# Altium schematic sheet 232 LAB Sheet.SchDoc("232 LAB Sheet")
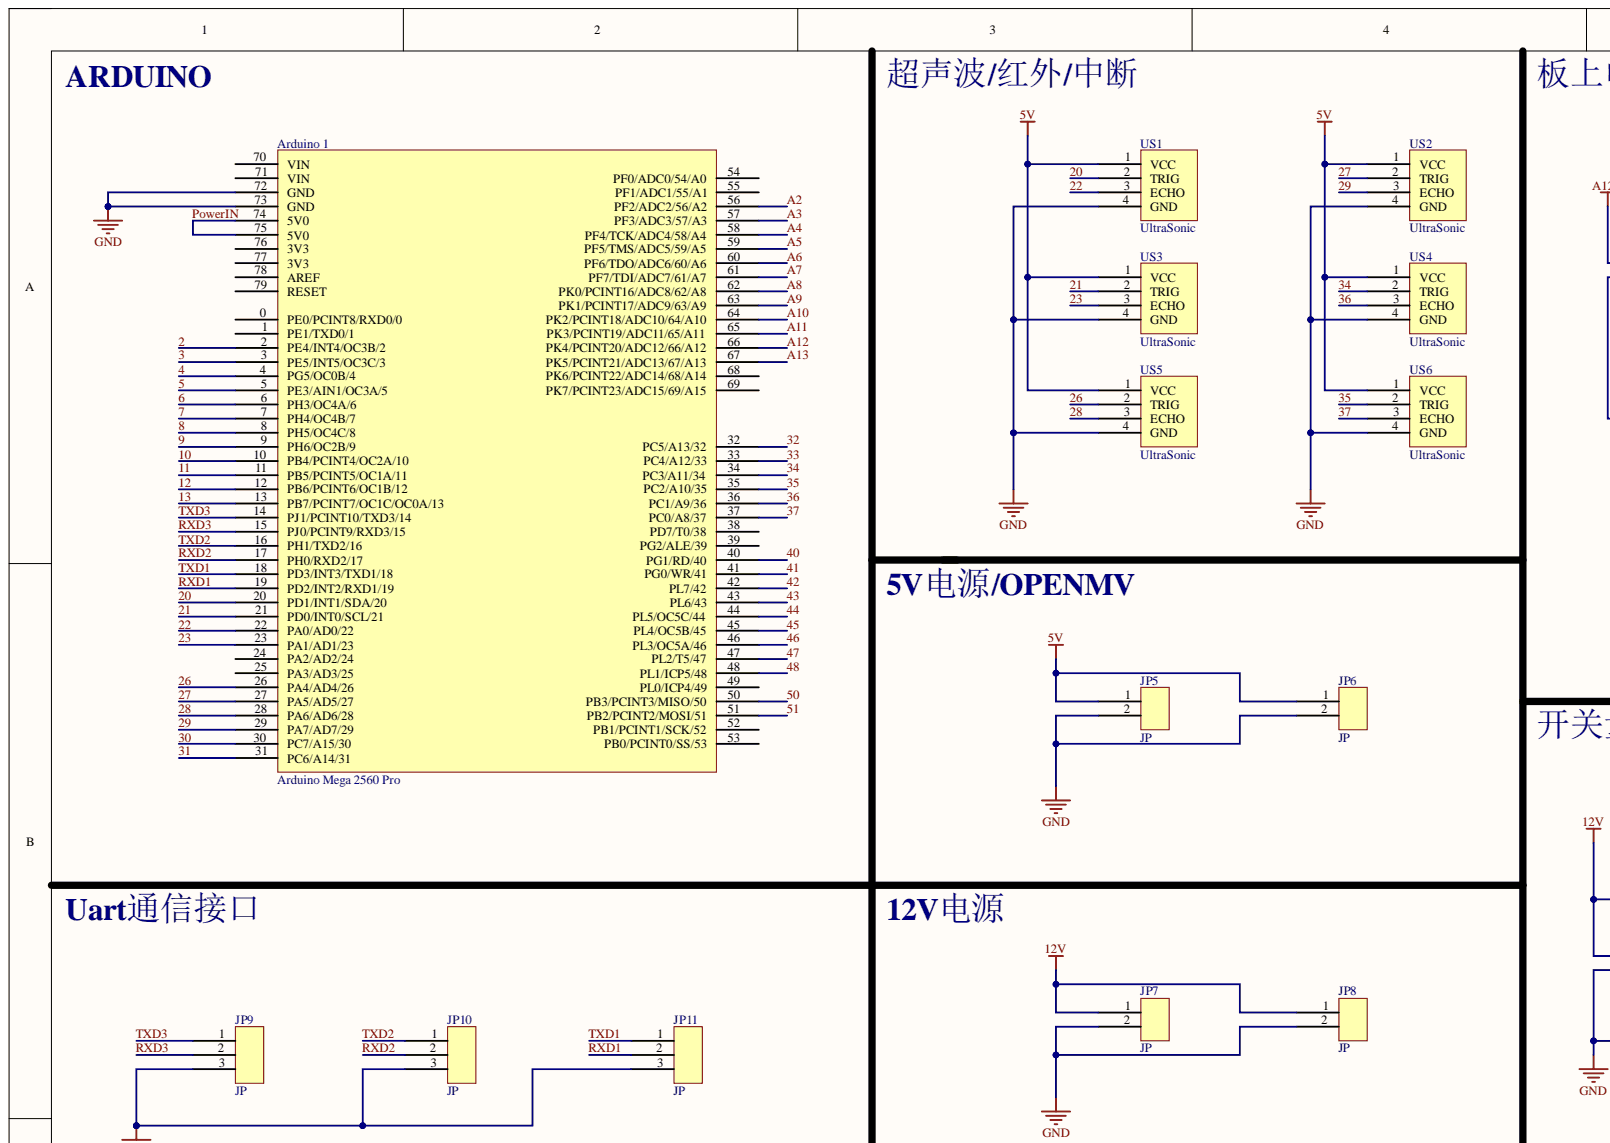
[diagram p0: 232 LAB Sheet.SchDoc, top left]
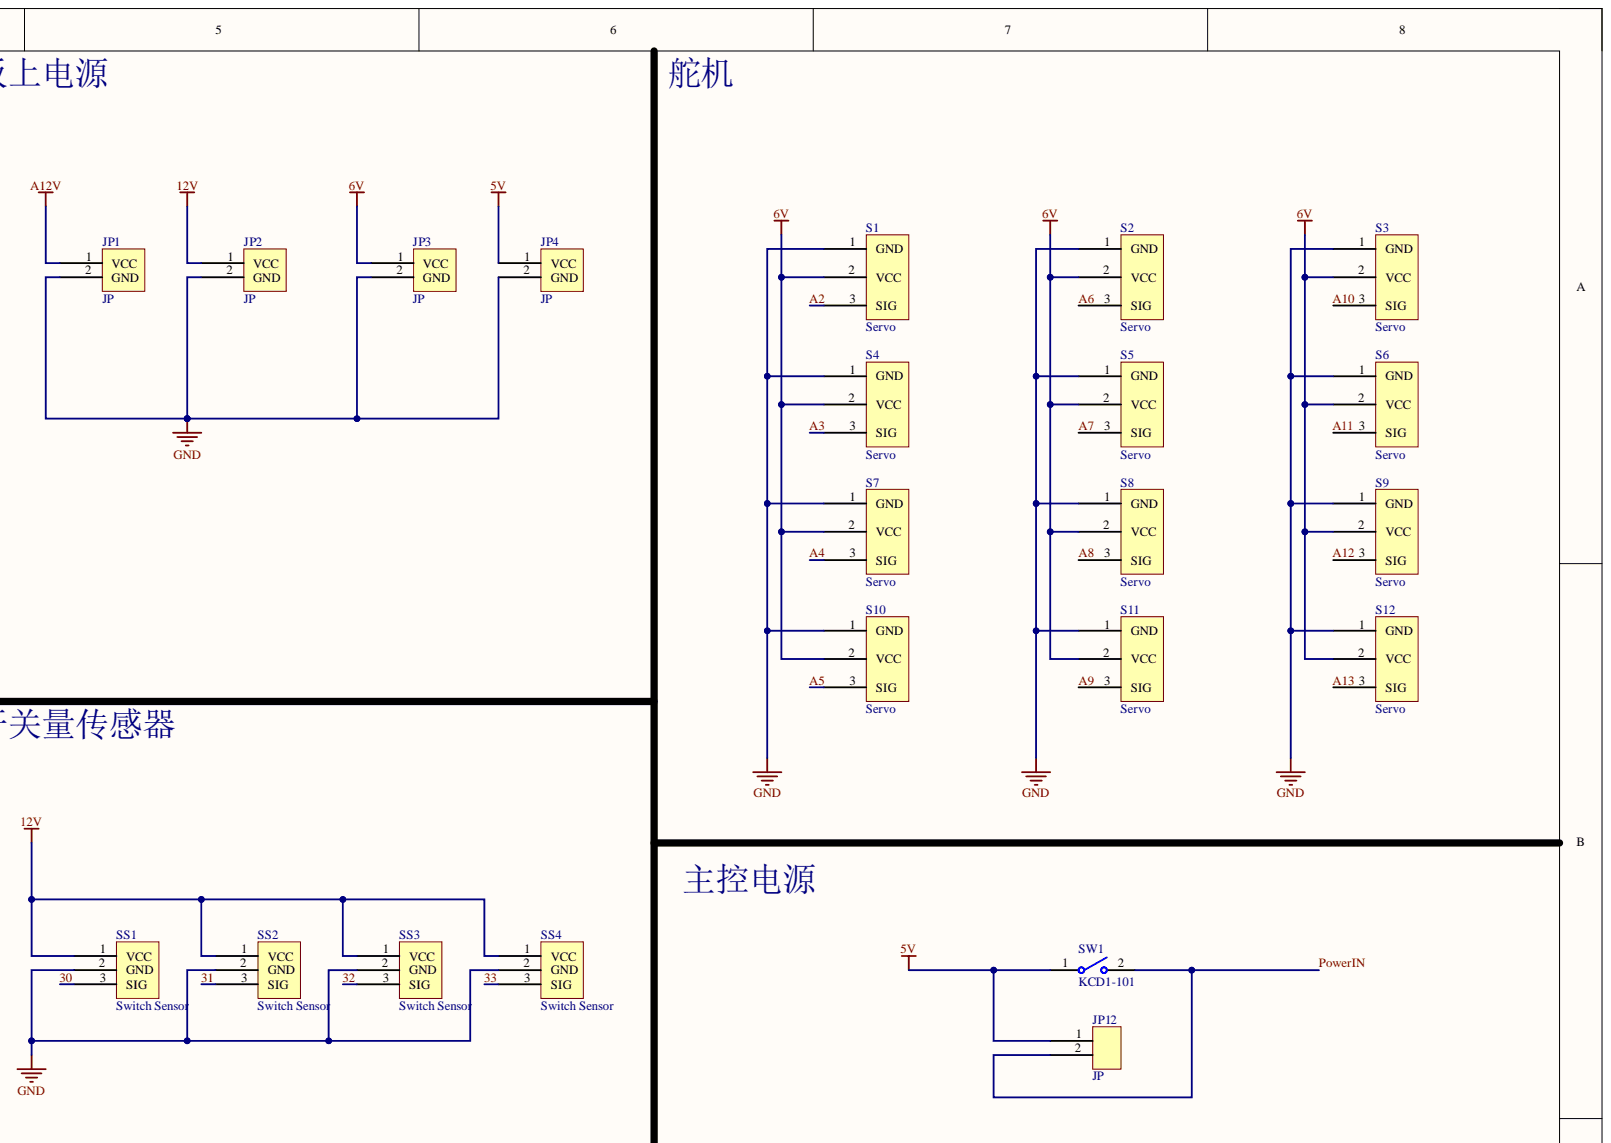
[diagram p1: 232 LAB Sheet.SchDoc, top right]
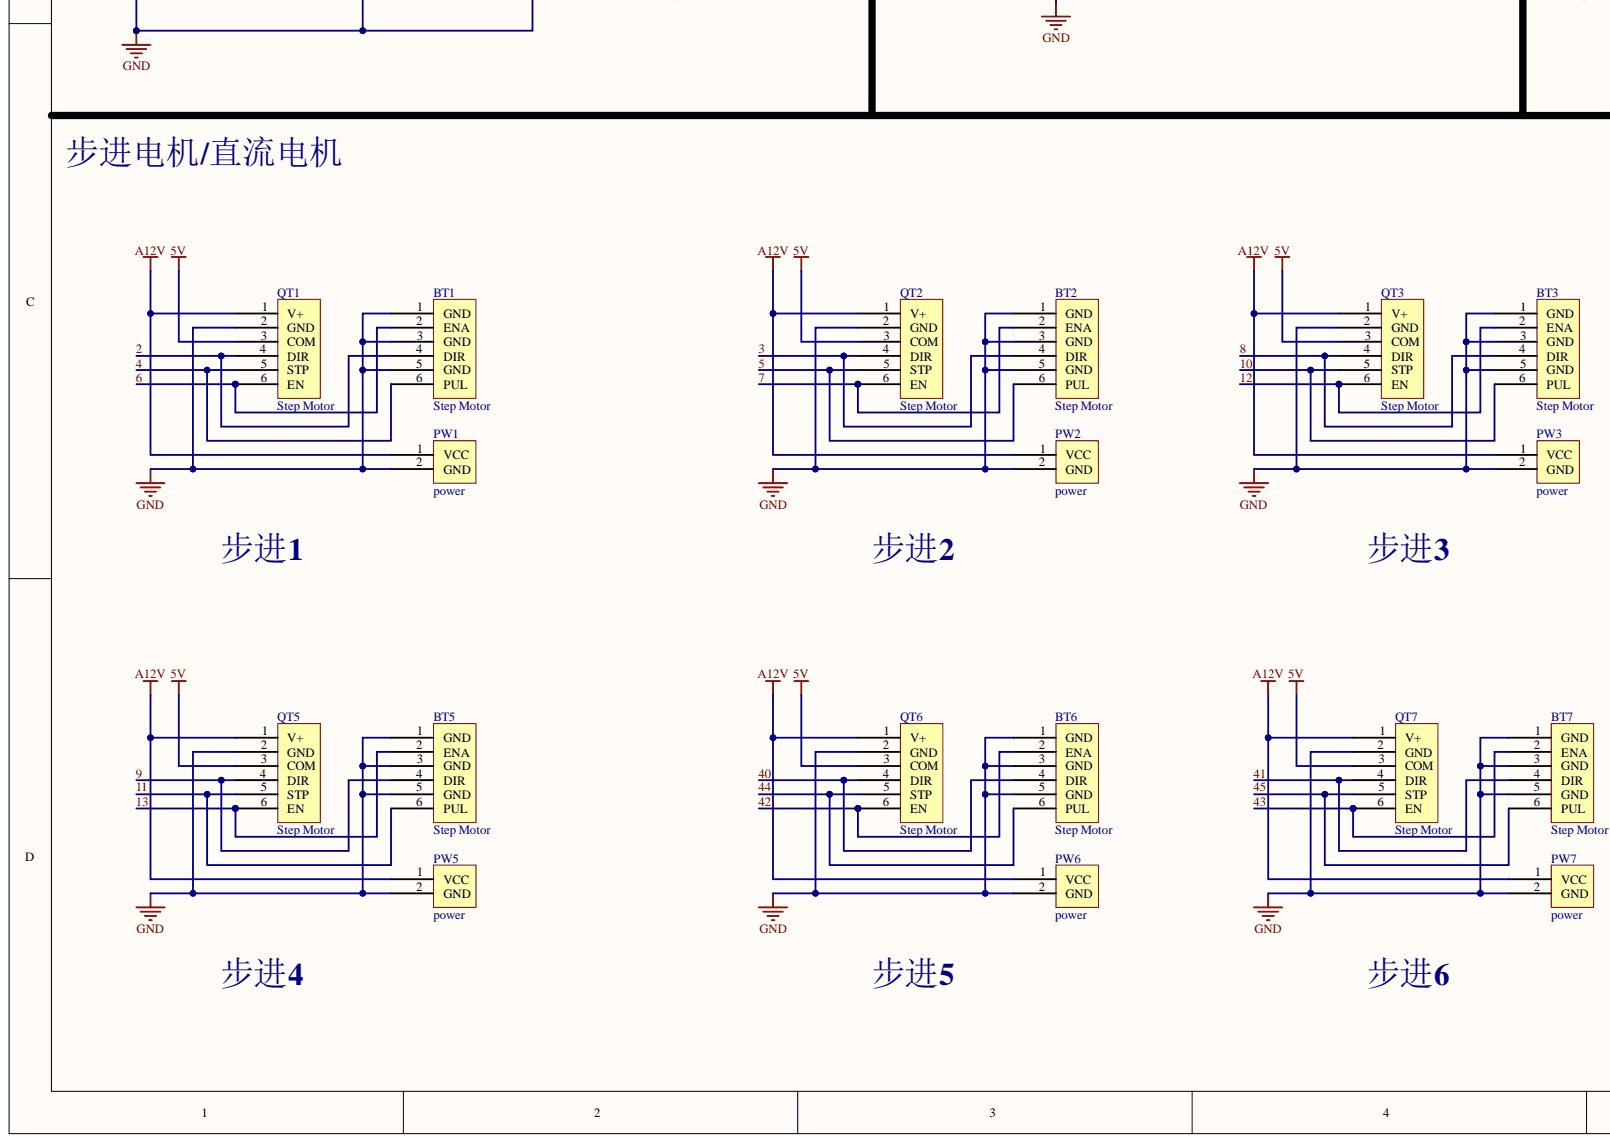
[diagram p2: 232 LAB Sheet.SchDoc, bottom left]
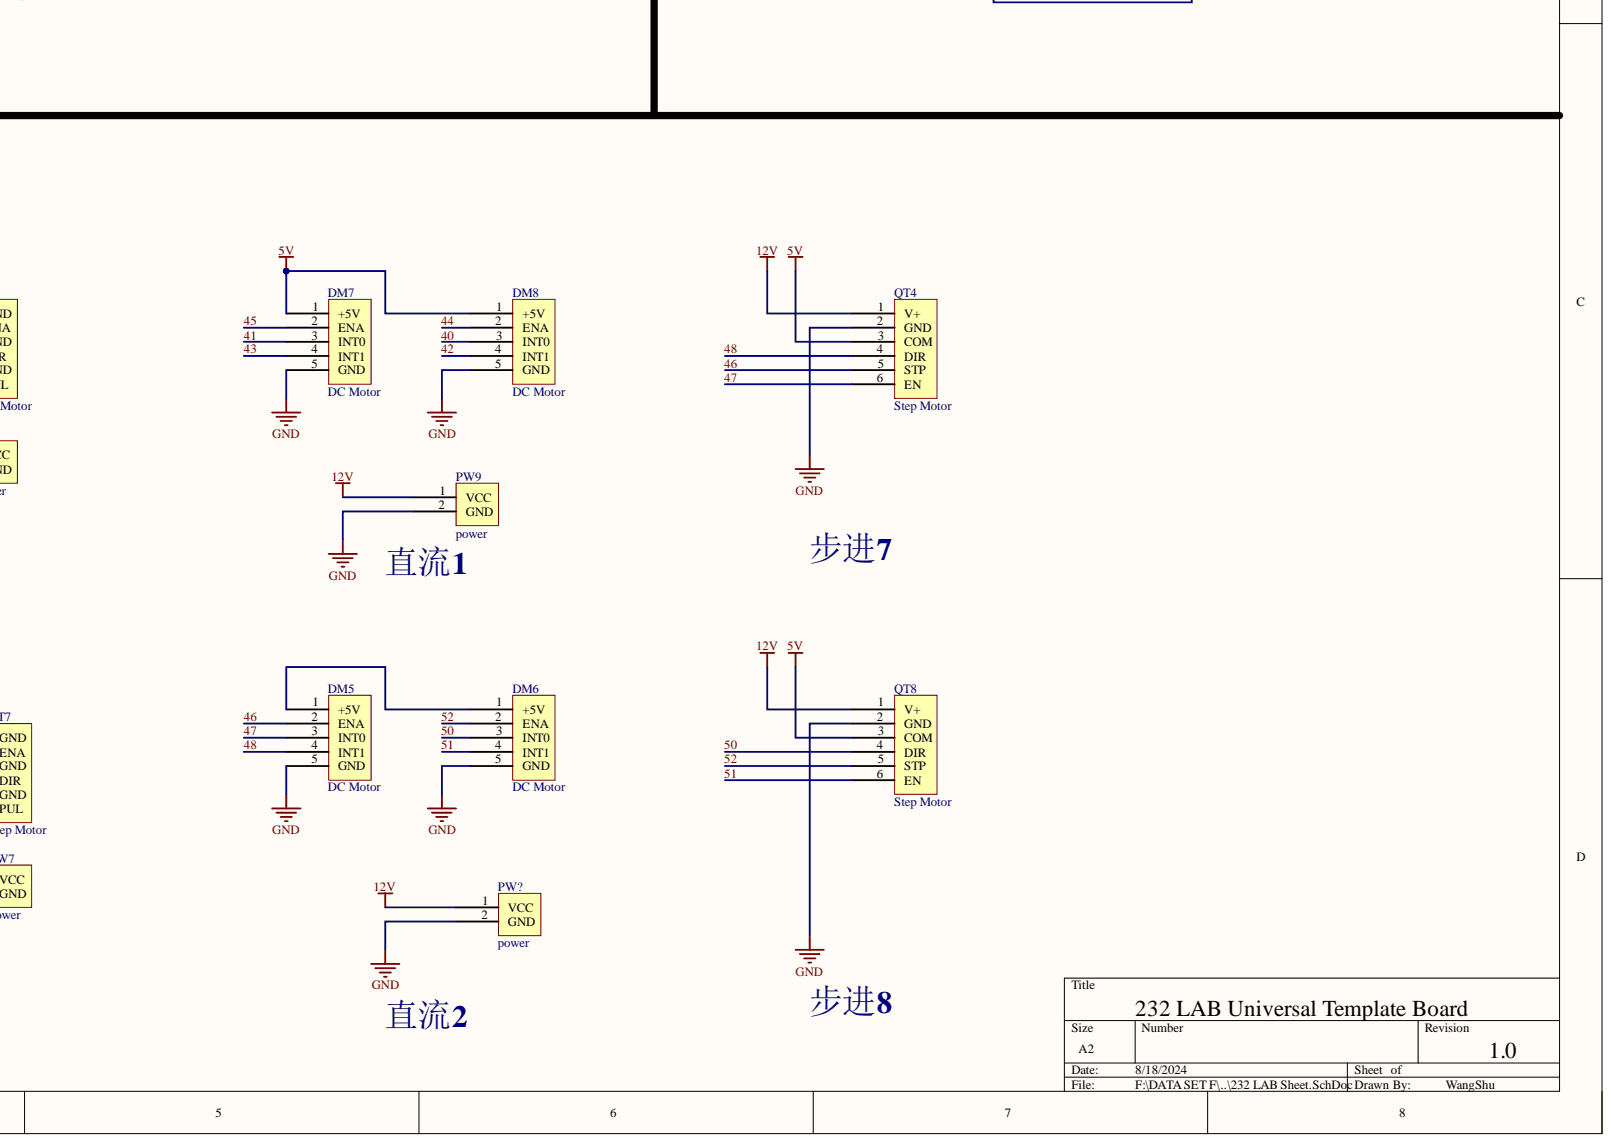
[diagram p3: 232 LAB Sheet.SchDoc, bottom right]

## Parts
Arduino_1  Arduino Mega 2560 Pro  [Mega2560Pro]
BT1  Step Motor  [XH2.54-6P]
BT2  Step Motor  [XH2.54-6P]
BT3  Step Motor  [XH2.54-6P]
BT5  Step Motor  [XH2.54-6P]
BT6  Step Motor  [XH2.54-6P]
BT7  Step Motor  [XH2.54-6P]
DM5  DC Motor  [XH2.54-5P]
DM6  DC Motor  [XH2.54-5P]
DM7  DC Motor  [XH2.54-5P]
DM8  DC Motor  [XH2.54-5P]
JP1  JP  [XT30]
JP2  JP  [XT30]
JP3  JP  [XT30]
JP4  JP  [XT30]
JP5  JP  [XH2.54-2P]
JP6  JP  [XH2.54-2P]
JP7  JP  [XH2.54-2P]
JP8  JP  [XH2.54-2P]
JP9  JP  [XH2.54-3p]
JP10  JP  [XH2.54-3p]
JP11  JP  [XH2.54-3p]
JP12  JP  [XH2.54-2P]
PW1  power  [XH2.54-2P]
PW2  power  [XH2.54-2P]
PW3  power  [XH2.54-2P]
PW5  power  [XH2.54-2P]
PW6  power  [XH2.54-2P]
PW7  power  [XH2.54-2P]
PW9  power  [XH2.54-2P]
PW?  power  [XH2.54-2P]
QT1  Step Motor  [XH2.54-6P]
QT2  Step Motor  [XH2.54-6P]
QT3  Step Motor  [XH2.54-6P]
QT4  Step Motor  [XH2.54-6P]
QT5  Step Motor  [XH2.54-6P]
QT6  Step Motor  [XH2.54-6P]
QT7  Step Motor  [XH2.54-6P]
QT8  Step Motor  [XH2.54-6P]
S1  Servo  [MR30]
S2  Servo  [MR30]
S3  Servo  [MR30]
S4  Servo  [MR30]
S5  Servo  [MR30]
S6  Servo  [MR30]
S7  Servo  [MR30]
S8  Servo  [MR30]
S9  Servo  [MR30]
S10  Servo  [MR30]
S11  Servo  [MR30]
S12  Servo  [MR30]
SS1  Switch Sensor  [XH2.54-3p]
SS2  Switch Sensor  [XH2.54-3p]
SS3  Switch Sensor  [XH2.54-3p]
SS4  Switch Sensor  [XH2.54-3p]
SW1  KCD1-101  [KCD1-101]
US1  UltraSonic  [XH2.54-4P]
US2  UltraSonic  [XH2.54-4P]
US3  UltraSonic  [XH2.54-4P]
US4  UltraSonic  [XH2.54-4P]
US5  UltraSonic  [XH2.54-4P]
US6  UltraSonic  [XH2.54-4P]

## Nets
5V: DM7.1(+5V) DM8.1(+5V) JP4.1 JP5.1 JP6.1 JP12.1 QT1.3(COM) QT2.3(COM) QT3.3(COM) QT4.3(COM) QT5.3(COM) QT6.3(COM) QT7.3(COM) QT8.3(COM) SW1.1 US1.1(VCC) US2.1(VCC) US3.1(VCC) US4.1(VCC) US5.1(VCC) US6.1(VCC)
6V: JP3.1 S1.2 S2.2 S3.2 S4.2 S5.2 S6.2 S7.2 S8.2 S9.2 S10.2 S11.2 S12.2
12V: JP2.1 JP7.1 JP8.1 PW9.1 PW?.1 QT4.1(V+) QT8.1(V+) SS1.1 SS2.1 SS3.1 SS4.1
A12V: JP1.1 PW1.1 PW2.1 PW3.1 PW5.1 PW6.1 PW7.1 QT1.1(V+) QT2.1(V+) QT3.1(V+) QT5.1(V+) QT6.1(V+) QT7.1(V+)
GND: Arduino_1.72(GND) Arduino_1.73(GND) BT1.1(GND) BT1.3(GND) BT1.5(GND) BT2.1(GND) BT2.3(GND) BT2.5(GND) BT3.1(GND) BT3.3(GND) BT3.5(GND) BT5.1(GND) BT5.3(GND) BT5.5(GND) BT6.1(GND) BT6.3(GND) BT6.5(GND) BT7.1(GND) BT7.3(GND) BT7.5(GND) DM5.5(GND) DM6.5(GND) DM7.5(GND) DM8.5(GND) JP1.2 JP2.2 JP3.2 JP4.2 JP5.2 JP6.2 JP7.2 JP8.2 JP9.3 JP10.3 JP11.3 PW1.2 PW2.2 PW3.2 PW5.2 PW6.2 PW7.2 PW9.2 PW?.2 QT1.2(GND) QT2.2(GND) QT3.2(GND) QT4.2(GND) QT5.2(GND) QT6.2(GND) QT7.2(GND) QT8.2(GND) S1.1 S2.1 S3.1 S4.1 S5.1 S6.1 S7.1 S8.1 S9.1 S10.1 S11.1 S12.1 SS1.2 SS2.2 SS3.2 SS4.2 US1.4(GND) US2.4(GND) US3.4(GND) US4.4(GND) US5.4(GND) US6.4(GND)
2: Arduino_1.2(PE4/INT4/OC3B/2) BT1.4(DIR) QT1.4(DIR)
3: Arduino_1.3(PE5/INT5/OC3C/3) BT2.4(DIR) QT2.4(DIR)
4: Arduino_1.4(PG5/OC0B/4) BT1.6(PUL) QT1.5(STP)
5: Arduino_1.5(PE3/AIN1/OC3A/5) BT2.6(PUL) QT2.5(STP)
6: Arduino_1.6(PH3/OC4A/6) BT1.2(ENA) QT1.6(EN)
7: Arduino_1.7(PH4/OC4B/7) BT2.2(ENA) QT2.6(EN)
8: Arduino_1.8(PH5/OC4C/8) BT3.4(DIR) QT3.4(DIR)
9: Arduino_1.9(PH6/OC2B/9) BT5.4(DIR) QT5.4(DIR)
10: Arduino_1.10(PB4/PCINT4/OC2A/10) BT3.6(PUL) QT3.5(STP)
11: Arduino_1.11(PB5/PCINT5/OC1A/11) BT5.6(PUL) QT5.5(STP)
12: Arduino_1.12(PB6/PCINT6/OC1B/12) BT3.2(ENA) QT3.6(EN)
13: Arduino_1.13(PB7/PCINT7/OC1C/OC0A/13) BT5.2(ENA) QT5.6(EN)
20: Arduino_1.20(PD1/INT1/SDA/20) US1.2(TRIG)
21: Arduino_1.21(PD0/INT0/SCL/21) US3.2(TRIG)
22: Arduino_1.22(PA0/AD0/22) US1.3(ECHO)
23: Arduino_1.23(PA1/AD1/23) US3.3(ECHO)
26: Arduino_1.26(PA4/AD4/26) US5.2(TRIG)
27: Arduino_1.27(PA5/AD5/27) US2.2(TRIG)
28: Arduino_1.28(PA6/AD6/28) US5.3(ECHO)
29: Arduino_1.29(PA7/AD7/29) US2.3(ECHO)
30: Arduino_1.30(PC7/A15/30) SS1.3
31: Arduino_1.31(PC6/A14/31) SS2.3
32: Arduino_1.32(PC5/A13/32) SS3.3
33: Arduino_1.33(PC4/A12/33) SS4.3
34: Arduino_1.34(PC3/A11/34) US4.2(TRIG)
35: Arduino_1.35(PC2/A10/35) US6.2(TRIG)
36: Arduino_1.36(PC1/A9/36) US4.3(ECHO)
37: Arduino_1.37(PC0/A8/37) US6.3(ECHO)
40: Arduino_1.40(PG1/RD/40) BT6.4(DIR) DM8.3(INT0) QT6.4(DIR)
41: Arduino_1.41(PG0/WR/41) BT7.4(DIR) DM7.3(INT0) QT7.4(DIR)
42: Arduino_1.42(PL7/42) BT6.2(ENA) DM8.4(INT1) QT6.6(EN)
43: Arduino_1.43(PL6/43) BT7.2(ENA) DM7.4(INT1) QT7.6(EN)
44: Arduino_1.44(PL5/OC5C/44) BT6.6(PUL) DM8.2(ENA) QT6.5(STP)
45: Arduino_1.45(PL4/OC5B/45) BT7.6(PUL) DM7.2(ENA) QT7.5(STP)
46: Arduino_1.46(PL3/OC5A/46) DM5.2(ENA) QT4.5(STP)
47: Arduino_1.47(PL2/T5/47) DM5.3(INT0) QT4.6(EN)
48: Arduino_1.48(PL1/ICP5/48) DM5.4(INT1) QT4.4(DIR)
50: Arduino_1.50(PB3/PCINT3/MISO/50) DM6.3(INT0) QT8.4(DIR)
51: Arduino_1.51(PB2/PCINT2/MOSI/51) DM6.4(INT1) QT8.6(EN)
52: DM6.2(ENA) QT8.5(STP)
A2: Arduino_1.56(PF2/ADC2/56/A2) S1.3
A3: Arduino_1.57(PF3/ADC3/57/A3) S4.3
A4: Arduino_1.58(PF4/TCK/ADC4/58/A4) S7.3
A5: Arduino_1.59(PF5/TMS/ADC5/59/A5) S10.3
A6: Arduino_1.60(PF6/TDO/ADC6/60/A6) S2.3
A7: Arduino_1.61(PF7/TDI/ADC7/61/A7) S5.3
A8: Arduino_1.62(PK0/PCINT16/ADC8/62/A8) S8.3
A9: Arduino_1.63(PK1/PCINT17/ADC9/63/A9) S11.3
A10: Arduino_1.64(PK2/PCINT18/ADC10/64/A10) S3.3
A11: Arduino_1.65(PK3/PCINT19/ADC11/65/A11) S6.3
A12: Arduino_1.66(PK4/PCINT20/ADC12/66/A12) S9.3
A13: Arduino_1.67(PK5/PCINT21/ADC13/67/A13) S12.3
PowerIN: Arduino_1.74(5V0) Arduino_1.75(5V0) JP12.2 SW1.2
RXD1: Arduino_1.19(PD2/INT2/RXD1/19) JP11.2
RXD2: Arduino_1.17(PH0/RXD2/17) JP10.2
RXD3: Arduino_1.15(PJ0/PCINT9/RXD3/15) JP9.2
TXD1: Arduino_1.18(PD3/INT3/TXD1/18) JP11.1
TXD2: Arduino_1.16(PH1/TXD2/16) JP10.1
TXD3: Arduino_1.14(PJ1/PCINT10/TXD3/14) JP9.1
NetDM5_1: DM5.1(+5V) DM6.1(+5V)

Not connected: Arduino_1 0(PE0/PCINT8/RXD0/0) 1(PE1/TXD0/1) 24(PA2/AD2/24) 25(PA3/AD3/25) 38(PD7/T0/38) 39(PG2/ALE/39) 49(PL0/ICP4/49) 52(PB1/PCINT1/SCK/52) 53(PB0/PCINT0/SS/53) 54(PF0/ADC0/54/A0) 55(PF1/ADC1/55/A1) 68(PK6/PCINT22/ADC14/68/A14) 69(PK7/PCINT23/ADC15/69/A15) 70(VIN) 71(VIN) 76(3V3) 77(3V3) 78(AREF) 79(RESET)

## Library data of the parts
Arduino_1 = Arduino Mega 2560 Pro: Arduino开发板; Component Kind: Standard; Library Name: Lab 232 Schlib.SchLib
BT1-BT3 BT5-BT7 = XH2.54-6pin-2: BT6600驱动 步进电机接口; Component Kind: Standard; Library Name: Lab 232 Schlib.SchLib
DM5-DM8 = XH2.54-5pin-1: 直流电机控制; Component Kind: Standard; Library Name: Lab 232 Schlib.SchLib
JP1-JP4 = XT30: XT30接口; Component Kind: Standard; Library Name: Lab 232 Schlib.SchLib
JP5-JP8 JP12 = XH2.54-2pin: 通用接口; Component Kind: Standard; Library Name: Lab 232 Schlib.SchLib
JP9-JP11 = XH2.54-3pin: 通用接口; Component Kind: Standard; Library Name: Lab 232 Schlib.SchLib
PW1-PW3 PW5-PW7 PW9 PW? = XH2.54-2pin-1: 电源接口; Component Kind: Standard; Library Name: Lab 232 Schlib.SchLib
QT1-QT8 = XH2.54-6pin-1: 七特驱动 步进电机接口; Component Kind: Standard; Library Name: Lab 232 Schlib.SchLib
S1-S12 = MR30-2: MR30舵机接口; Component Kind: Standard; Library Name: Lab 232 Schlib.SchLib
SS1-SS4 = XH2.54-3pin-1: 开关量传感器接口; Component Kind: Standard; Library Name: Lab 232 Schlib.SchLib
US1-US6 = XH2.54-4pin-1: 超声波传感器; Component Kind: Standard; Library Name: Lab 232 Schlib.SchLib
SW1 = KCD1-101: Component Kind: Standard; Library Name: Lab 232 Schlib.SchLib
